# Altium schematic sheet H18R2_Frontend.SchDoc
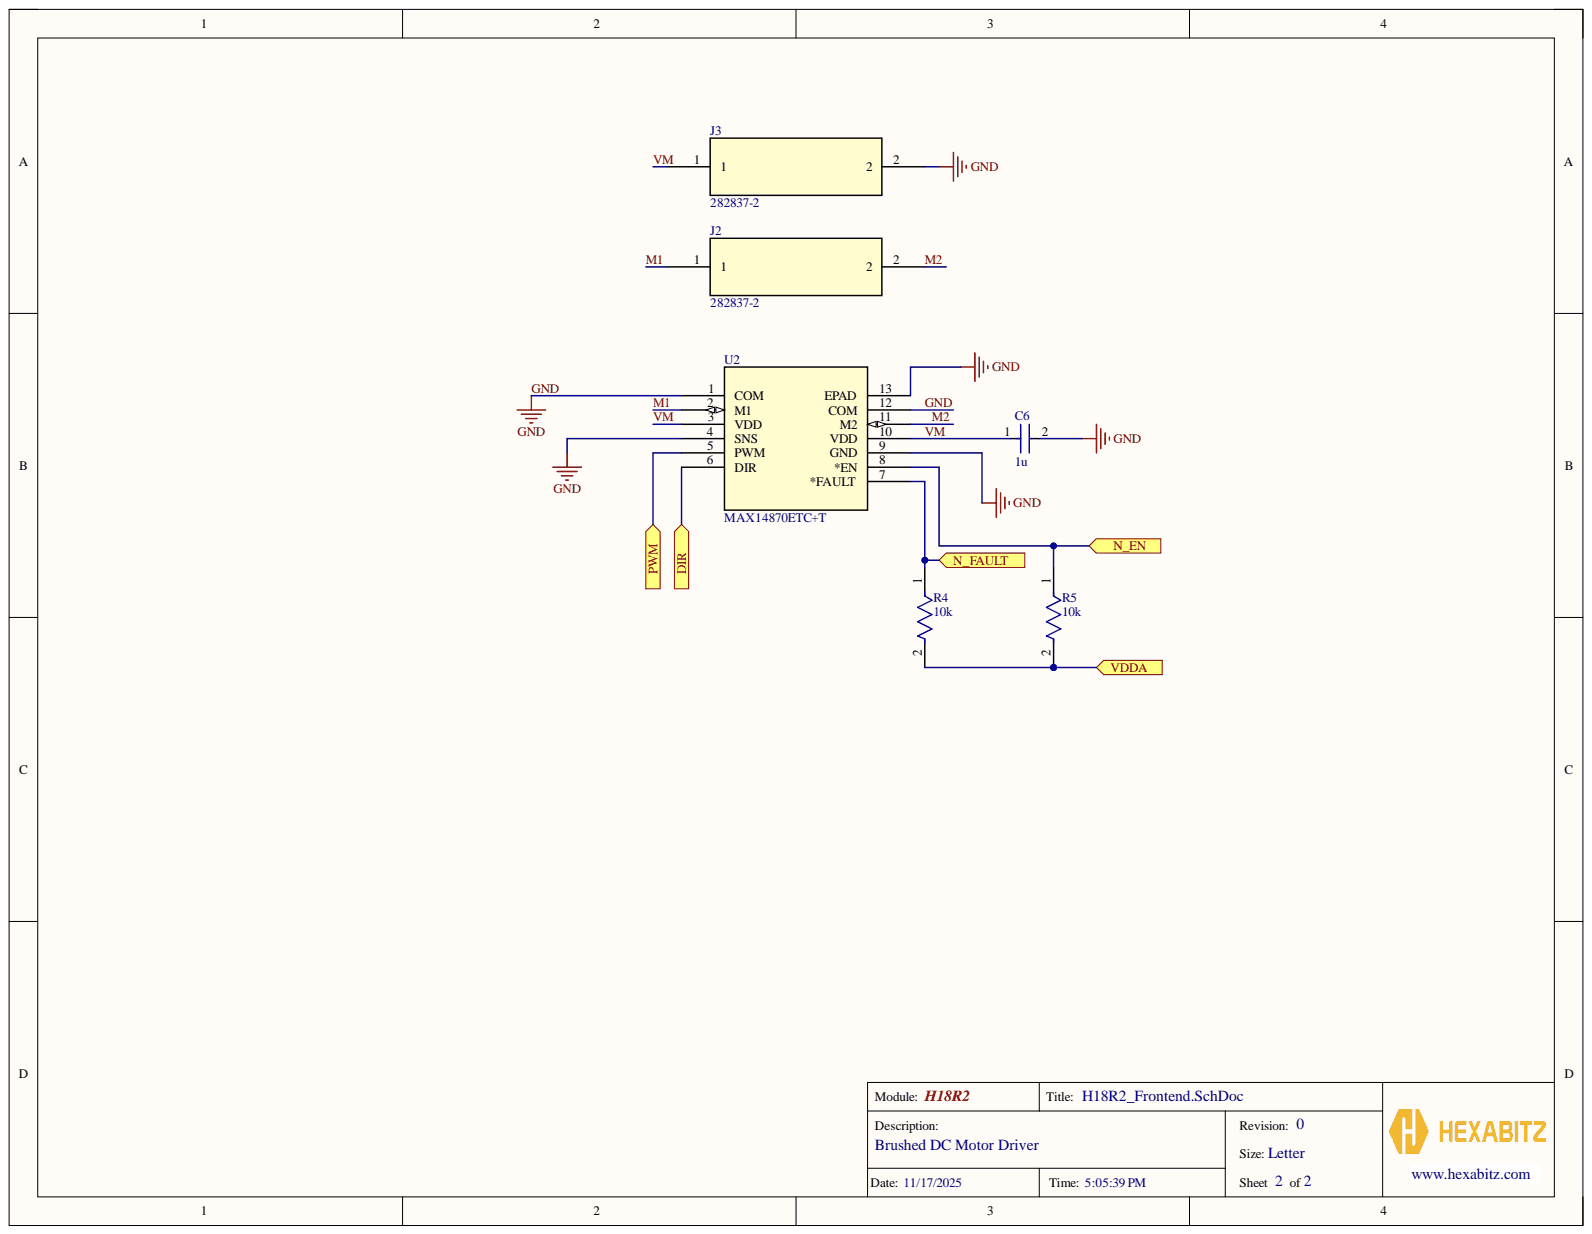
[diagram p0: H18R2_Frontend.SchDoc]

## Parts
C6  1u  [FP-0603-L_1_6_0_15-W_0_8-IPC_C]
J2  282837-2  [282837-2_TYC]
J3  282837-2  [282837-2_TYC]
R4  10k  [FP-SG73P1J-MFG]
R5  10k  [FP-SG73P1J-MFG]
U2  MAX14870ETC+T  [21-0664B_MXM]

## Ports
DIR, N_EN, N_FAULT, PWM, VDDA

## Nets
DIR: U2.6(DIR) ; port DIR
GND: C6.2 J3.2 U2.1(COM) U2.4(SNS) U2.9(GND) U2.12(COM) U2.13(EPAD)
M1: J2.1 U2.2(M1)
M2: J2.2 U2.11(M2)
N_EN: R5.1 U2.8(*EN) ; port N_EN
N_FAULT: R4.1 U2.7(*FAULT) ; port N_FAULT
PWM: U2.5(PWM) ; port PWM
VDDA: R4.2 R5.2 ; port VDDA
VM: C6.1 J3.1 U2.3(VDD) U2.10(VDD)

## Library data of the parts
C6 = CMP-2000-07531-4: Ceramic Capacitor General Use 1uF ±10% 50V X7R 0603; Capacitance: 1uF; Case Code (Imperial): 0603; Case Code (Metric): 1608; Case/Package: 0603; Component Kind: Standard; Construction: Flat; Depth: 0.8mm; Dielectric: X7R; Halogen Free: Halogen Free; Height: 0.8mm; Length: 1.6mm; Library Name: Altium Content Vault; Max Operating Temperature: 125°C; Min Operating Temperature: -55°C; Mount: Surface Mount; Package Quantity: 1; Packaging: Tape and Reel; Radiation Hardening: No; RoHS Compliant: Yes; Series: M; Termination: SMD/SMT; Thickness: 0.037inch; Tolerance: 10%; Voltage Rating: 50V; Voltage Rating (DC): 50V; Width: 0.031inch
J2 J3 = CMP-007-000000-1: TERMI-BLOK PCB MOUNT 2P; Manufacturer_Name: TE Connectivity; Component Kind: Standard; Design Item ID: 282837-2; Manufacturer_Part_Number: 282837-2; RefDes: RefDes; Source: 282837-2.SchLib; Type: Type; Copyright: Copyright (C) 2025 Ultra Librarian. All rights reserved.; Library Name: Nate Fischer
R4 R5 = CMP-00683-07748074-1: SG73P1JTTD1002F Res Thick Film 0603 10K Ohm 1% 0.2W(1/5W) ±100ppm/C Pad SMD Automotive T/R; Power Rating: 200mW; Resistance: 10kΩ; Temperature Coefficient: 100ppm/°C; Voltage Rating: 50V; Case Code (Imperial): 0603; Case Code (Metric): 1608; Case/Package: 0603; Component Kind: Standard; Library Name: Altium Content Vault; Max Operating Temperature: 155°C; Min Operating Temperature: -55°C; NexarPartId: 7748074; Number of Pins: 2; RoHS: Compliant; Termination: SMD/SMT; Tolerance: 1%; Voltage Rating (DC): 50V
U2 = MAX14870ETC+T: Compact 4.5V to 36V Full-Bridge DC Motor Drivers; Manufacturer_Name: Analog Devices / Maxim Integrated; Component Kind: Standard; Library Name: MAX14870ETC&plus_T.SchLib; Manufacturer_Part_Number: MAX14870ETC+T; RefDes: RefDes; Type: Type; Copyright: Copyright (C) 2025 Ultra Librarian. All rights reserved.
